# Altium schematic sheet Sensor.SchDoc(Sensor)
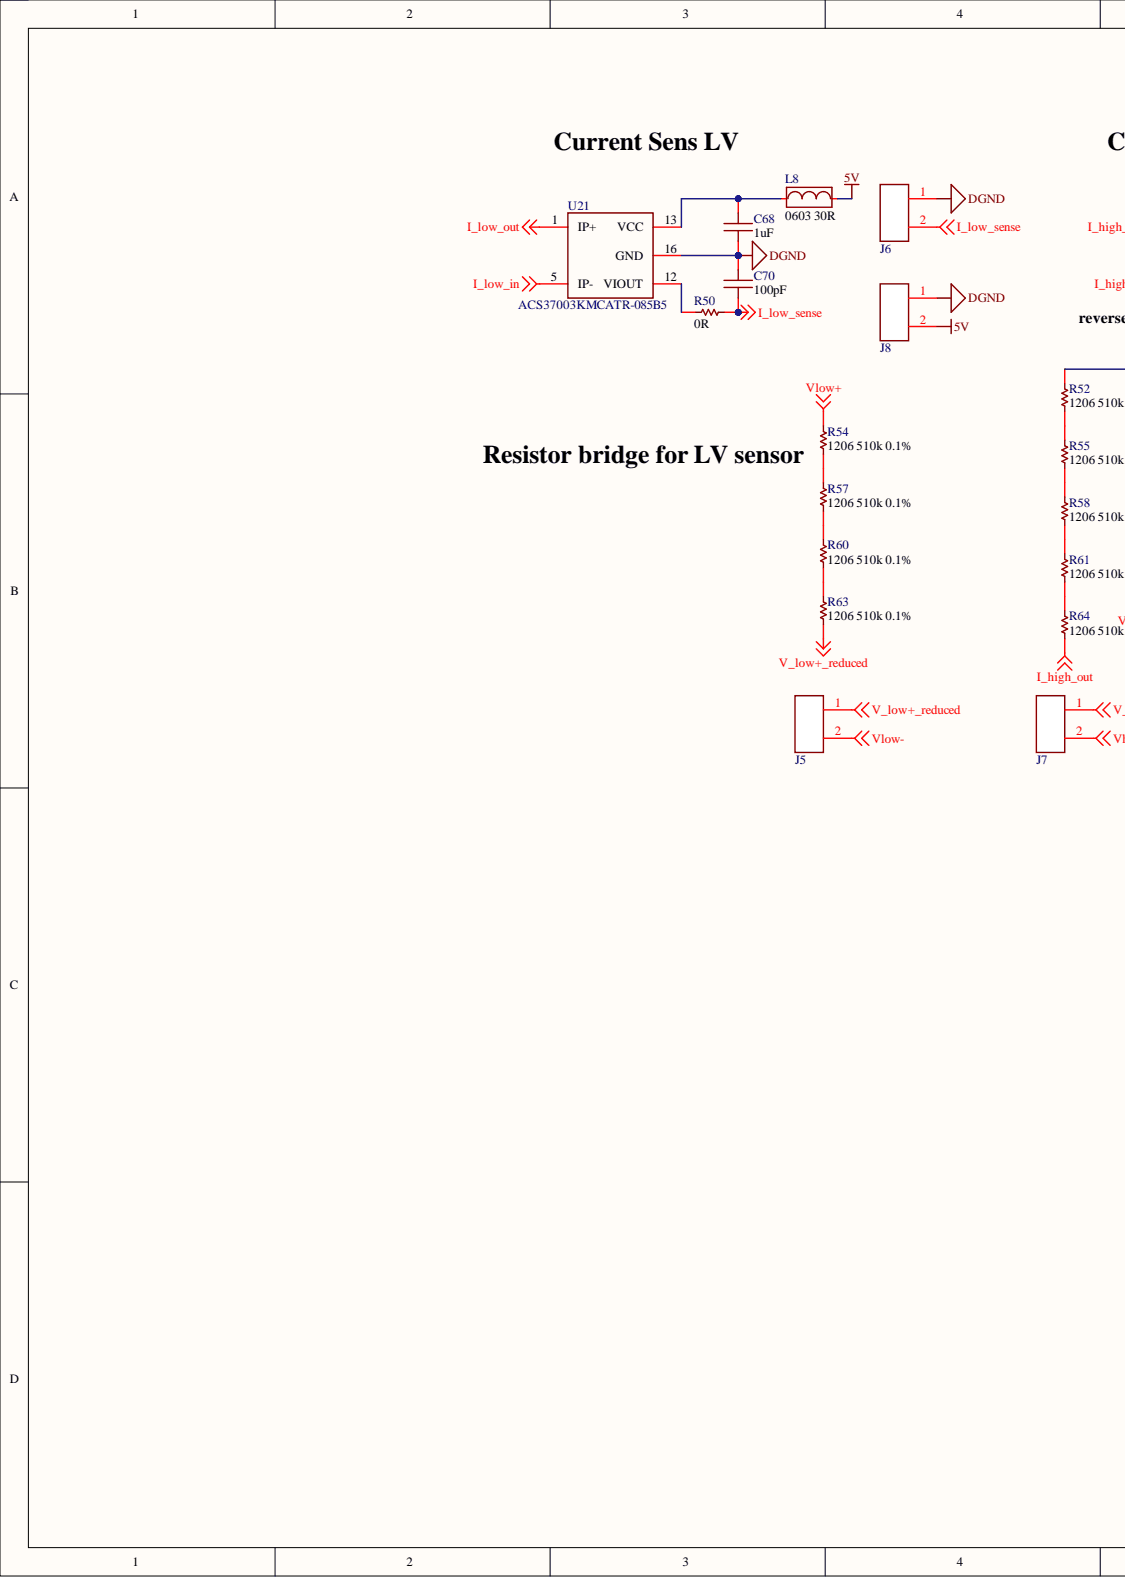
[diagram p0: Sensor.SchDoc, left]
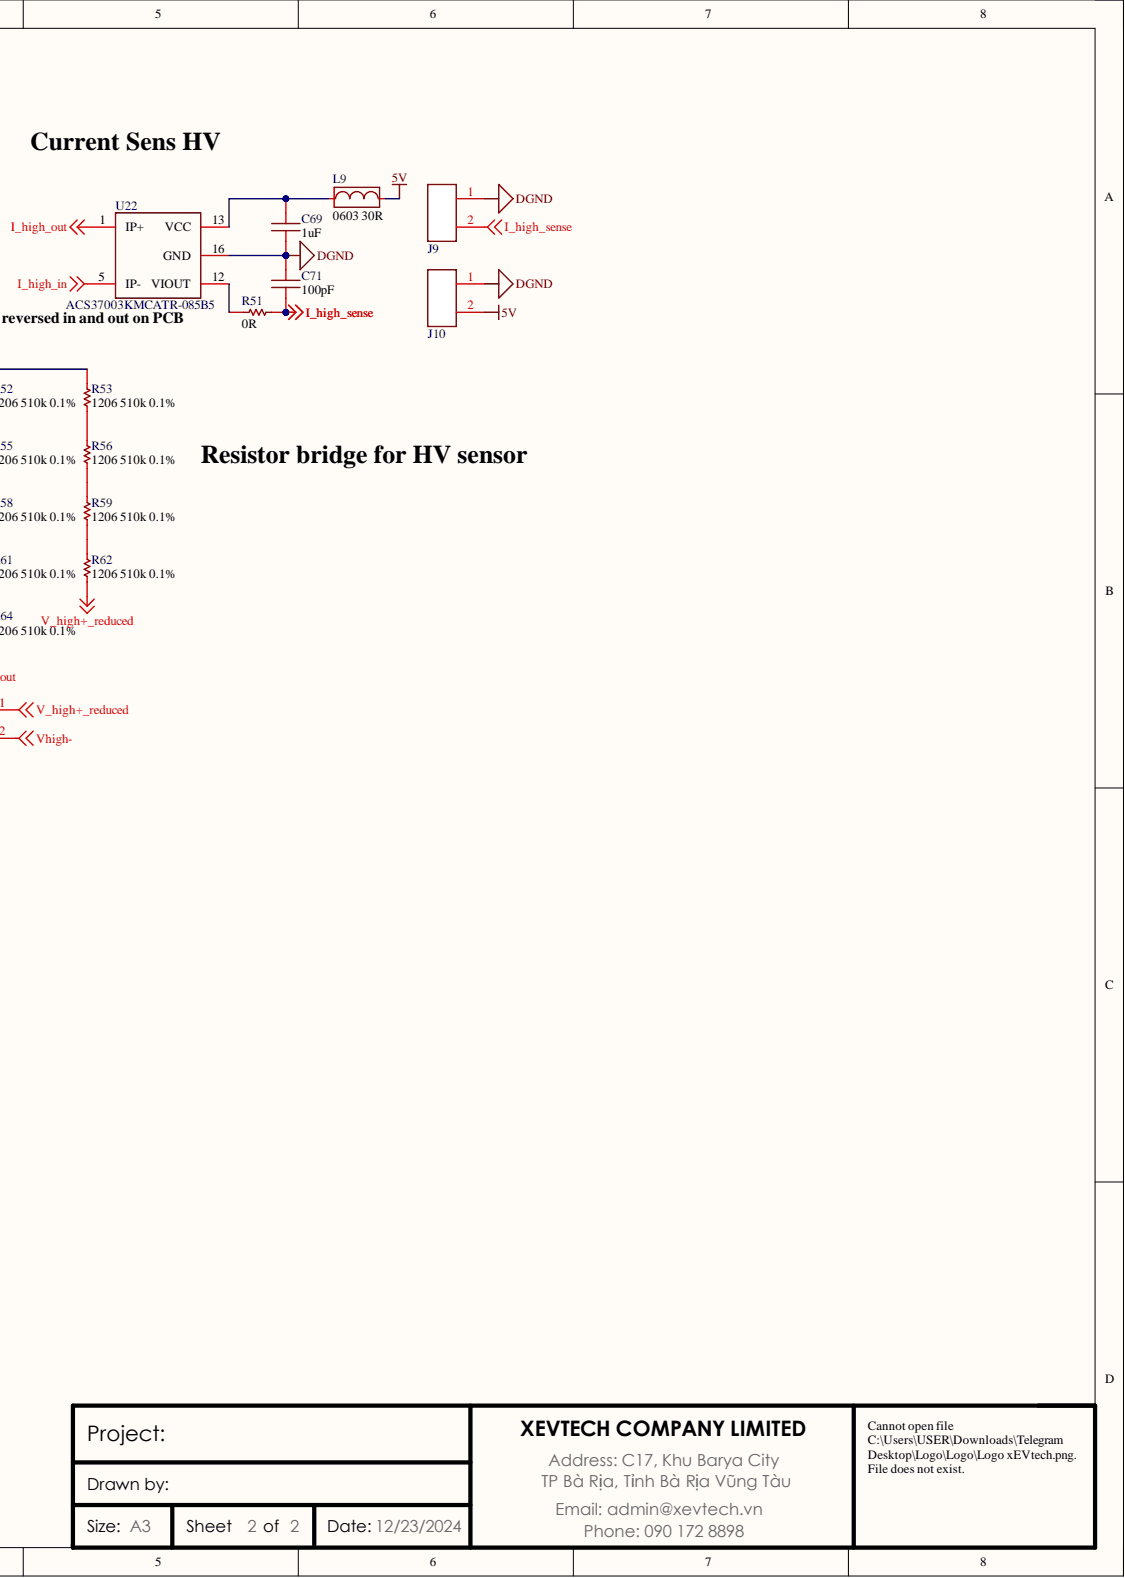
[diagram p1: Sensor.SchDoc, right]

## Parts
C68  value 1uF  Capacitance: 1uF  [CAP 0603]  (hidden comment: GCM188R71C105KA64J)
C69  value 1uF  Capacitance: 1uF  [CAP 0603]  (hidden comment: GCM188R71C105KA64J)
C70  Capacitance: 100pF  [CAP 0603]  (hidden comment: C0603C101J4GAC7867)
C71  Capacitance: 100pF  [CAP 0603]  (hidden comment: C0603C101J4GAC7867)
J5  FTR-102-03-S-S  [header male smd 2pin-127_2]  (value not drawn)
J6  FTR-102-03-S-S  [header male smd 2pin-127_2]  (value not drawn)
J7  FTR-102-03-S-S  [header male smd 2pin-127_2]  (value not drawn)
J8  FTR-102-03-S-S  [header male smd 2pin-127_2]  (value not drawn)
J9  FTR-102-03-S-S  [header male smd 2pin-127_2]  (value not drawn)
J10  FTR-102-03-S-S  [header male smd 2pin-127_2]  (value not drawn)
L8  Case Code (Imperial): 0603  Parameter 1: 0603 30R  [Bead 1608 0603]  (hidden comment: BLM18KG300JH1D)
L9  Case Code (Imperial): 0603  Parameter 1: 0603 30R  [Bead 1608 0603]  (hidden comment: BLM18KG300JH1D)
R50  value 0R  Resistance: 0R  [RES 0603]  (hidden comment: AC0603JR-130RL)
R51  value 0R  Resistance: 0R  [RES 0603]  (hidden comment: AC0603JR-130RL)
R52  RP1206BRD07510KL  [RES 1206]  (value not drawn)
R53  Parameter 1: 1206 510k 0.1%  [RES 1206]  (hidden comment: RP1206BRD07510KL)
R54  RP1206BRD07510KL  [RES 1206]  (value not drawn)
R55  RP1206BRD07510KL  [RES 1206]  (value not drawn)
R56  Parameter 1: 1206 510k 0.1%  [RES 1206]  (hidden comment: RP1206BRD07510KL)
R57  RP1206BRD07510KL  [RES 1206]  (value not drawn)
R58  RP1206BRD07510KL  [RES 1206]  (value not drawn)
R59  Parameter 1: 1206 510k 0.1%  [RES 1206]  (hidden comment: RP1206BRD07510KL)
R60  RP1206BRD07510KL  [RES 1206]  (value not drawn)
R61  RP1206BRD07510KL  [RES 1206]  (value not drawn)
R62  Parameter 1: 1206 510k 0.1%  [RES 1206]  (hidden comment: RP1206BRD07510KL)
R63  RP1206BRD07510KL  [RES 1206]  (value not drawn)
R64  RP1206BRD07510KL  [RES 1206]  (value not drawn)
U21  ACS37003KMCATR-085B5  [ACS37003KMC]
U22  ACS37003KMCATR-085B5  [ACS37003KMC]

## Nets
5V: J8.2 J10.2 L8.2 L9.2
DGND: C68.2 C69.2 C70.1 C71.1 J6.1 J8.1 J9.1 J10.1 U21.16(GND) U22.16(GND)
I_high_in: U22.5(IP-)
I_high_out: R64.1 U22.1(IP+)
I_high_sense: C71.2 J9.2 R51.2
I_low_in: U21.5(IP-)
I_low_out: U21.1(IP+)
I_low_sense: C70.2 J6.2 R50.2
V_high+_reduced: J7.1 R62.1
V_low+_reduced: J5.1 R63.1
Vhigh-: J7.2
Vlow+: R54.2
Vlow-: J5.2
NetC68_1: C68.1 L8.1 U21.13(VCC)
NetC69_1: C69.1 L9.1 U22.13(VCC)
NetR50_1: R50.1 U21.12(VIOUT)
NetR51_1: R51.1 U22.12(VIOUT)
NetR52_1: R52.1 R55.2
NetR52_2: R52.2 R53.2
NetR53_1: R53.1 R56.2
NetR54_1: R54.1 R57.2
NetR55_1: R55.1 R58.2
NetR56_1: R56.1 R59.2
NetR57_1: R57.1 R60.2
NetR58_1: R58.1 R61.2
NetR59_1: R59.1 R62.2
NetR60_1: R60.1 R63.2
NetR61_1: R61.1 R64.2

## Library data of the parts
C68 C69 = Cap 0603 1uF: CAP, CERM, 1 µF, 16 V, +/- 10%, X7R, 0603; Manufacturer 1: Murata; Category 1: Ceramic Capacitors; Description 1: Multilayer Ceramic Capacitor, 1 uF, 16 V, ± 10%, X7R, 0603 [1608 Metric]; Voltage Rating: 16V; Case Code (Imperial): 0603; Case Code (Metric): 1608; Case/Package: 0603; Component Kind: Standard; ComponentLink1Description: https://datasheet.ciiva.com/26947/getdatasheetpartid-789976-26947662.pdf?src-supplier=Verical; ComponentLink1URL: https://datasheet.ciiva.com/26947/getdatasheetpartid-789976-26947662.pdf?src-supplier=Verical; Depth: 0.8mm; Dielectric: X7R; Lead Free: Lead Free; Length: 1.6mm; Manufacturer Part Number 1: GCM188R71C105KA64J; Max Operating Temperature: 125°C; Min Operating Temperature: -55°C; Mount: Surface Mount; Packaging: Tape and Reel; Pricing 1: 1=0,18, 10=0,119, 50=0,075, 100=0,0601, 500=0,04338, 1000=0,03838, 2500=0,03671, 5000=0,03337 (USD); Radiation Hardening: No; Ratings: AEC-Q200; RoHS 1: Yes; RoHS Compliant: Yes; Series: GCM; Stock 1: 62628; Supplier 1: Digi-Key; Supplier Part Number 1: 490-12657-6-ND; Thickness: 0.035inch; Tolerance: 10%; Voltage Rating (DC): 16V; Width: 0.031inch; Library Name: xEV_SmartOBC.SchLib
C70 C71 = CAP 0603 100pF: CAP, CERM, 100 pF, 16 V, +/- 5%, C0G,NP0, 0603; Manufacturer 1: KEMET; Category 1: Ceramic Capacitors; Description 1: Cap Ceramic 100pF 16V C0G 5% SMD 0603 125C Paper T/R; Dielectric Material: Ceramic; Material: 4241ConductorMaterial; Temperature Coefficient: 30ppm/°C; Voltage Rating: 16V; Case/Package: 0603; Component Kind: Standard; ComponentLink1Description: https://api.kemet.com/component-edge/download/datasheet/C0603C101J4GACTU.pdf?src-supplier=Digi-Key; ComponentLink1URL: https://api.kemet.com/component-edge/download/datasheet/C0603C101J4GACTU.pdf?src-supplier=Digi-Key; ComponentLink2Description: https://search.kemet.com/component-edge/download/datasheet/C0603C101J4GACTU.pdf?src-supplier=Digi-Key; ComponentLink2URL: https://search.kemet.com/component-edge/download/datasheet/C0603C101J4GACTU.pdf?src-supplier=Digi-Key; ComponentLink3Description: http://capacitoredge.kemet.com/capedge2/DataSheet?pn=C0603C101J4GAC7867&src-supplier=Avnet; ComponentLink3URL: http://capacitoredge.kemet.com/capedge2/DataSheet?pn=C0603C101J4GAC7867&src-supplier=Avnet; ComponentLink4Description: http://capacitoredge.kemet.com/capedge2/DataSheet?pn=C0603C101J4GAC7867; ComponentLink4URL: http://capacitoredge.kemet.com/capedge2/DataSheet?pn=C0603C101J4GAC7867; Construction: Flat; Depth: 0.8mm; Dielectric: C0G; Height: 0.8mm; Lead Free: Lead Free; Length: 1.6mm; Manufacturer Part Number 1: C0603C101J4GAC7867; Max Operating Temperature: 125°C; Min Operating Temperature: -55°C; Mount: Surface Mount; Packaging: Tape and Reel; Parameter 1: 100pF; Pricing 1: 1=0,23, 10=0,151, 50=0,1062, 100=0,0858, 500=0,06376, 1000=0,05578 (USD); Radiation Hardening: No; RoHS 1: Yes; RoHS Compliant: Yes; Series: SMD Comm C0G; Stock 1: 12614; Supplier 1: Digi-Key; Supplier Part Number 1: 399-14476-6-ND; Tolerance: 5%; Voltage Rating (DC): 16V; Library Name: xEV_SmartOBC.SchLib
J5-J10 = Header male SM 2p 1.27mm_2: Connector Header Surface Mount 2 position 0.050" (1.27mm); Manufacturer 1: Samtec; Category 1: Connectors; Description 1: Headers & Wire Housings Surface Mount Micro Header, 0.050 x 0.100 Pitch; Component Kind: Standard; ComponentLink1Description: http://www.farnell.com/datasheets/1810770.pdf?src-supplier=Farnell; ComponentLink1URL: http://www.farnell.com/datasheets/1810770.pdf?src-supplier=Farnell; ComponentLink2Description: https://datasheet.octopart.com/FTR-102-03-S-S-Samtec-datasheet-13696950.pdf?src-supplier=Newark; ComponentLink2URL: https://datasheet.octopart.com/FTR-102-03-S-S-Samtec-datasheet-13696950.pdf?src-supplier=Newark; ComponentLink3Description: https://datasheet.octopart.com/FTR-102-03-S-S-Samtec-datasheet-24969242.pdf?src-supplier=Farnell; ComponentLink3URL: https://datasheet.octopart.com/FTR-102-03-S-S-Samtec-datasheet-24969242.pdf?src-supplier=Farnell; ComponentLink4Description: http://www.samtec.com/documents/webfiles/pdf/FTR_SMT.pdf?src-supplier=Avnet; ComponentLink4URL: http://www.samtec.com/documents/webfiles/pdf/FTR_SMT.pdf?src-supplier=Avnet; ComponentLink5Description: https://datasheet.octopart.com/FTR-102-03-S-S-Samtec-datasheet-136395375.pdf?src-supplier=Toby+Electronics; ComponentLink5URL: https://datasheet.octopart.com/FTR-102-03-S-S-Samtec-datasheet-136395375.pdf?src-supplier=Toby+Electronics; ComponentLink6Description: https://datasheet.octopart.com/FTR-102-03-S-S-Samtec-datasheet-151312691.pdf?src-supplier=Sager+Electronics; ComponentLink6URL: https://datasheet.octopart.com/FTR-102-03-S-S-Samtec-datasheet-151312691.pdf?src-supplier=Sager+Electronics; ComponentLink7Description: https://datasheet.octopart.com/FTR-102-03-S-S-Samtec-datasheet-97028732.pdf?src-supplier=Samtec; ComponentLink7URL: https://datasheet.octopart.com/FTR-102-03-S-S-Samtec-datasheet-97028732.pdf?src-supplier=Samtec; Manufacturer Part Number 1: FTR-102-03-S-S; Pricing 1: 1=0,97, 10=0,817, 100=0,7333, 1000=0,53328, 5000=0,46662, 10000=0,44329 (USD); RoHS 1: Yes; Stock 1: 4034; Supplier 1: Digikey; Supplier Part Number 1: 612-FTR-102-03-S-S-ND; Value: Header Male; Library Name: xEV_SmartOBC.SchLib
L8 L9 = Bead-1608-0603: FERRITE BEAD 30 OHM 0603 1LN; Manufacturer 1: Murata; Category 1: Ferrite Beads and Chips; Description 1: Ferrite Beads Multi-Layer Power 30Ohm 25% 100MHz 3.3A 0.01Ohm DCR 0603 Automotive T/R; Max Current Rating: 3.3A; Number of Lines: 1; Case Code (Metric): 1608; Case/Package: 0603; Component Kind: Standard; ComponentLink1Description: https://www.murata.com/en-us/products/productdata/8796733866014/S0594E.pdf?src-supplier=Digi-Key; ComponentLink1URL: https://www.murata.com/en-us/products/productdata/8796733866014/S0594E.pdf?src-supplier=Digi-Key; ComponentLink2Description: http://www.murata.com/en-us/products/productdata/8798137286686/QNFA9129.pdf?src-supplier=Digi-Key; ComponentLink2URL: http://www.murata.com/en-us/products/productdata/8798137286686/QNFA9129.pdf?src-supplier=Digi-Key; Manufacturer Part Number 1: BLM18KG300JH1D; Max Operating Temperature: 150°C; Min Operating Temperature: -55°C; Mount: Surface Mount; Pricing 1: 1=0,11, 10=0,086, 25=0,0772, 50=0,0566, 100=0,0489, 250=0,03864, 500=0,03262, 1000=0,02747 (USD); Ratings: AEC-Q200; RoHS 1: Yes; RoHS Compliant: Yes; Series: EMIFIL®, BLM18K; Stock 1: 16948; Supplier 1: Digi-Key; Supplier Part Number 1: 490-14027-1-ND; Library Name: xEV_SmartOBC.SchLib
R50 R51 = RES_0603_0: RES 0 OHM JUMPER 1/10W 0603; Manufacturer 1: Yageo; Category 1: Chip SMD Resistors; Description 1: Zero Ohm Resistor, Jumper, 0603 [1608Metric], Thick Film, 100 mW, 1 A, Surface Mount; Power Rating: 100mW; Tolerance: 5%; Voltage Rating: 75V; Case/Package: 0603; Component Kind: Standard; ComponentLink1Description: https://datasheet.octopart.com/AC0603JR-130RL-Yageo-datasheet-166720450.pdf?src-supplier=Future+Electronics; ComponentLink1URL: https://datasheet.octopart.com/AC0603JR-130RL-Yageo-datasheet-166720450.pdf?src-supplier=Future+Electronics; Height: 550um; Lead Free: Lead Free; Manufacturer Part Number 1: AC0603JR-130RL; Max Operating Temperature: 155°C; Min Operating Temperature: -55°C; Packaging: 10Packaging; Pricing 1: 1=0,1, 10=0,021, 50=0,0124, 100=0,0103, 500=0,0069, 1000=0,00589, 5000=0,00423, 10000=0,00371 (USD); RoHS 1: Yes; RoHS Compliant: Yes; Stock 1: 426577; Supplier 1: Digi-Key; Supplier Part Number 1: 13-AC0603JR-130RLDKR-ND; Library Name: xEV_SmartOBC.SchLib
R52 R54 R55 R57 R58 R60 R61 R63 R64 = RES_1206_510k_0.1%: 510 kOhms ±0.1% 0.25W, 1/4W Chip Resistor 1206 (3216 Metric) Anti-Sulfur, Automotive AEC-Q200, Moisture Resistant Thin Film; Parameter 1: 1206 510k 0.1%; Component Kind: Standard; Supplier 1: Digi-Key; Supplier Part Number 1: 13-RP1206BRD07510KLCT-ND; Library Name: xEV_SmartOBC.SchLib
R53 R56 R59 R62 = RES_1206_510k_0.1%: 510 kOhms ±0.1% 0.25W, 1/4W Chip Resistor 1206 (3216 Metric) Anti-Sulfur, Automotive AEC-Q200, Moisture Resistant Thin Film; Component Kind: Standard; Supplier 1: Digi-Key; Supplier Part Number 1: 13-RP1206BRD07510KLCT-ND; Library Name: xEV_SmartOBC.SchLib
U21 U22 = ACS37003KMCATR-085B5: Current Sensor 85A 1 Channel Hall Effect Bidirectional 16-SOIC (0.295", 7.50mm Width); Manufacturer 1: Allegro MicroSystems; Category 1: Uncategorized; Description 1: 400KHZ, High Accuracy Current Se; Component Kind: Standard; ComponentLink1Description: https://datasheet.ciiva.com/23941/1756801-23941968.pdf?src-supplier=Newark; ComponentLink1URL: https://datasheet.ciiva.com/23941/1756801-23941968.pdf?src-supplier=Newark; Manufacturer Part Number 1: ACS37003KMCATR-085B5; Pricing 1: 1=8,99, 10=7,191, 25=6,652, 100=5,753 (USD); RoHS 1: No; Stock 1: 1455; Supplier 1: Digi-Key; Supplier Part Number 1: 620-ACS37003KMCATR-085B5CT-ND; Library Name: xEV_SmartOBC.SchLib
